# Altium schematic sheet Connectors.SchDoc
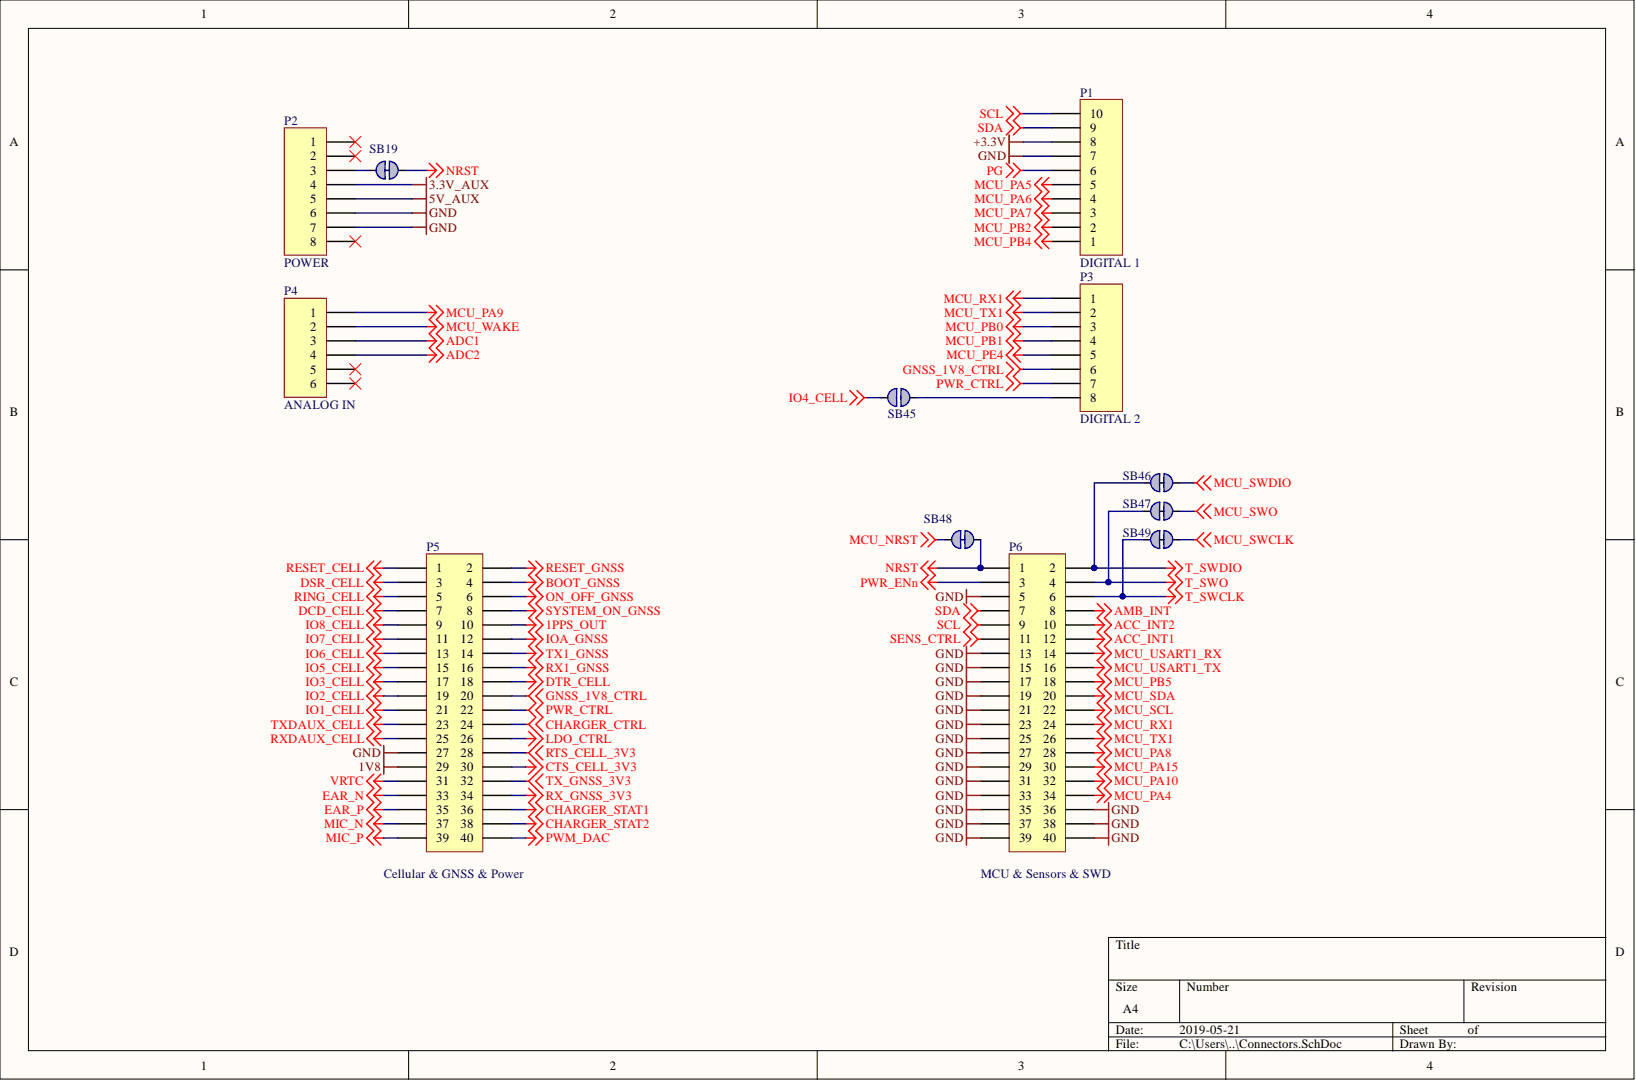
[diagram p0: Connectors.SchDoc]

## Parts
P1  DIGITAL 1  [HDR1X10]
P2  POWER  [HDR1X8]  (no BOM)
P3  DIGITAL 2  [HDR1X8]  (no BOM)
P4  ANALOG IN  [HDR1X6]  (no BOM)
P5  Cellular & GNSS & Power  [HDR2X20]  (no BOM)
P6  MCU & Sensors & SWD  [HDR2X20]  (no BOM)
SB19  Solderbridge  [Solderbridge_Shorted]  (value not drawn)
SB45  Solderbridge  [Solderbridge]  (value not drawn)  (no BOM)
SB46  Solderbridge  [Solderbridge_Shorted]  (value not drawn)
SB47  Solderbridge  [Solderbridge_Shorted]  (value not drawn)
SB48  Solderbridge  [Solderbridge_Shorted]  (value not drawn)
SB49  Solderbridge  [Solderbridge_Shorted]  (value not drawn)

## Nets
1PPS_OUT: P5.10
1V8: P5.29
3.3V_AUX: P2.4
5V_AUX: P2.5
+3.3V: P1.8
ACC_INT1: P6.12
ACC_INT2: P6.10
ADC1: P4.3
ADC2: P4.4
AMB_INT: P6.8
BOOT_GNSS: P5.4
CHARGER_CTRL: P5.24
CHARGER_STAT1: P5.36
CHARGER_STAT2: P5.38
CTS_CELL_3V3: P5.30
DCD_CELL: P5.7
DSR_CELL: P5.3
DTR_CELL: P5.18
EAR_N: P5.33
EAR_P: P5.35
GND: P1.7 P2.6 P2.7 P5.27 P6.5 P6.13 P6.15 P6.17 P6.19 P6.21 P6.23 P6.25 P6.27 P6.29 P6.31 P6.33 P6.35 P6.36 P6.37 P6.38 P6.39 P6.40
GNSS_1V8_CTRL: P3.6 P5.20
IO1_CELL: P5.21
IO2_CELL: P5.19
IO3_CELL: P5.17
IO4_CELL: SB45.2
IO5_CELL: P5.15
IO6_CELL: P5.13
IO7_CELL: P5.11
IO8_CELL: P5.9
IOA_GNSS: P5.12
LDO_CTRL: P5.26
MCU_NRST: SB48.1
MCU_PA4: P6.34
MCU_PA5: P1.5
MCU_PA6: P1.4
MCU_PA7: P1.3
MCU_PA8: P6.28
MCU_PA9: P4.1
MCU_PA10: P6.32
MCU_PA15: P6.30
MCU_PB0: P3.3
MCU_PB1: P3.4
MCU_PB2: P1.2
MCU_PB4: P1.1
MCU_PB5: P6.18
MCU_PE4: P3.5
MCU_RX1: P3.1 P6.24
MCU_SCL: P6.22
MCU_SDA: P6.20
MCU_SWCLK: SB49.2
MCU_SWDIO: SB46.2
MCU_SWO: SB47.2
MCU_TX1: P3.2 P6.26
MCU_USART1_RX: P6.14
MCU_USART1_TX: P6.16
MCU_WAKE: P4.2
MIC_N: P5.37
MIC_P: P5.39
NRST: P6.1 SB19.1 SB48.2
ON_OFF_GNSS: P5.6
PG: P1.6
PWM_DAC: P5.40
PWR_CTRL: P3.7 P5.22
PWR_ENn: P6.3
RESET_CELL: P5.1
RESET_GNSS: P5.2
RING_CELL: P5.5
RTS_CELL_3V3: P5.28
RX1_GNSS: P5.16
RX_GNSS_3V3: P5.34
RXDAUX_CELL: P5.25
SCL: P1.10 P6.9
SDA: P1.9 P6.7
SENS_CTRL: P6.11
SYSTEM_ON_GNSS: P5.8
T_SWCLK: P6.6 SB49.1
T_SWDIO: P6.2 SB46.1
T_SWO: P6.4 SB47.1
TX1_GNSS: P5.14
TX_GNSS_3V3: P5.32
TXDAUX_CELL: P5.23
VRTC: P5.31
NetP2_3: P2.3 SB19.2
NetP3_8: P3.8 SB45.1

Not connected: P2 1 2 8; P4 5 6

## Library data of the parts
P1 = Header 10: Manufacturer: SparkFun Electronics; Manufacturer Part No.: PRT-11417; Part Description: Arduino Stackable Header Kit; Specification: 10 pins; Value: PRT-11417; Component Kind: Standard; Library Name: Miscellaneous Connectors.IntLib; Signal Integrity: Connector; Supplier: Digi-Key; Supplier part number: 1568-1413-ND
P2 P3 = Header 8: Part Description: Arduino Connector; Specification: 8 pins; Library Name: Miscellaneous Connectors.IntLib; Signal Integrity: Connector
P4 = Header 6: Part Description: Arduino Connector; Specification: 6 pins; Library Name: Miscellaneous Connectors.IntLib; Signal Integrity: Connector
P5 P6 = Header 20X2: Manufacturer: MPE-Garry; Manufacturer Part No.: 087-2-040-0-S-XS0-1260; Part Description: Typical Header; Specification: 40 pins, 2 rows, 2.54 mm pitch; Value: 087-2-040-0-S-XS0-1260; Library Name: Miscellaneous Connectors.IntLib; Link: https://www.rutronik24.com/product/mpegarry/087-2-040-0-s-xs0-1260/6123588.html; Signal Integrity: Connector; Supplier: Rutronik; Supplier part number: COREC36718
SB19 SB46-SB49 = Solderbridge Shorted: Component Kind: Net Tie; Value: Solderbridge
SB45 = Solderbridge: Value: Solderbridge
